# Altium schematic sheet TrickleCharging.SchDoc
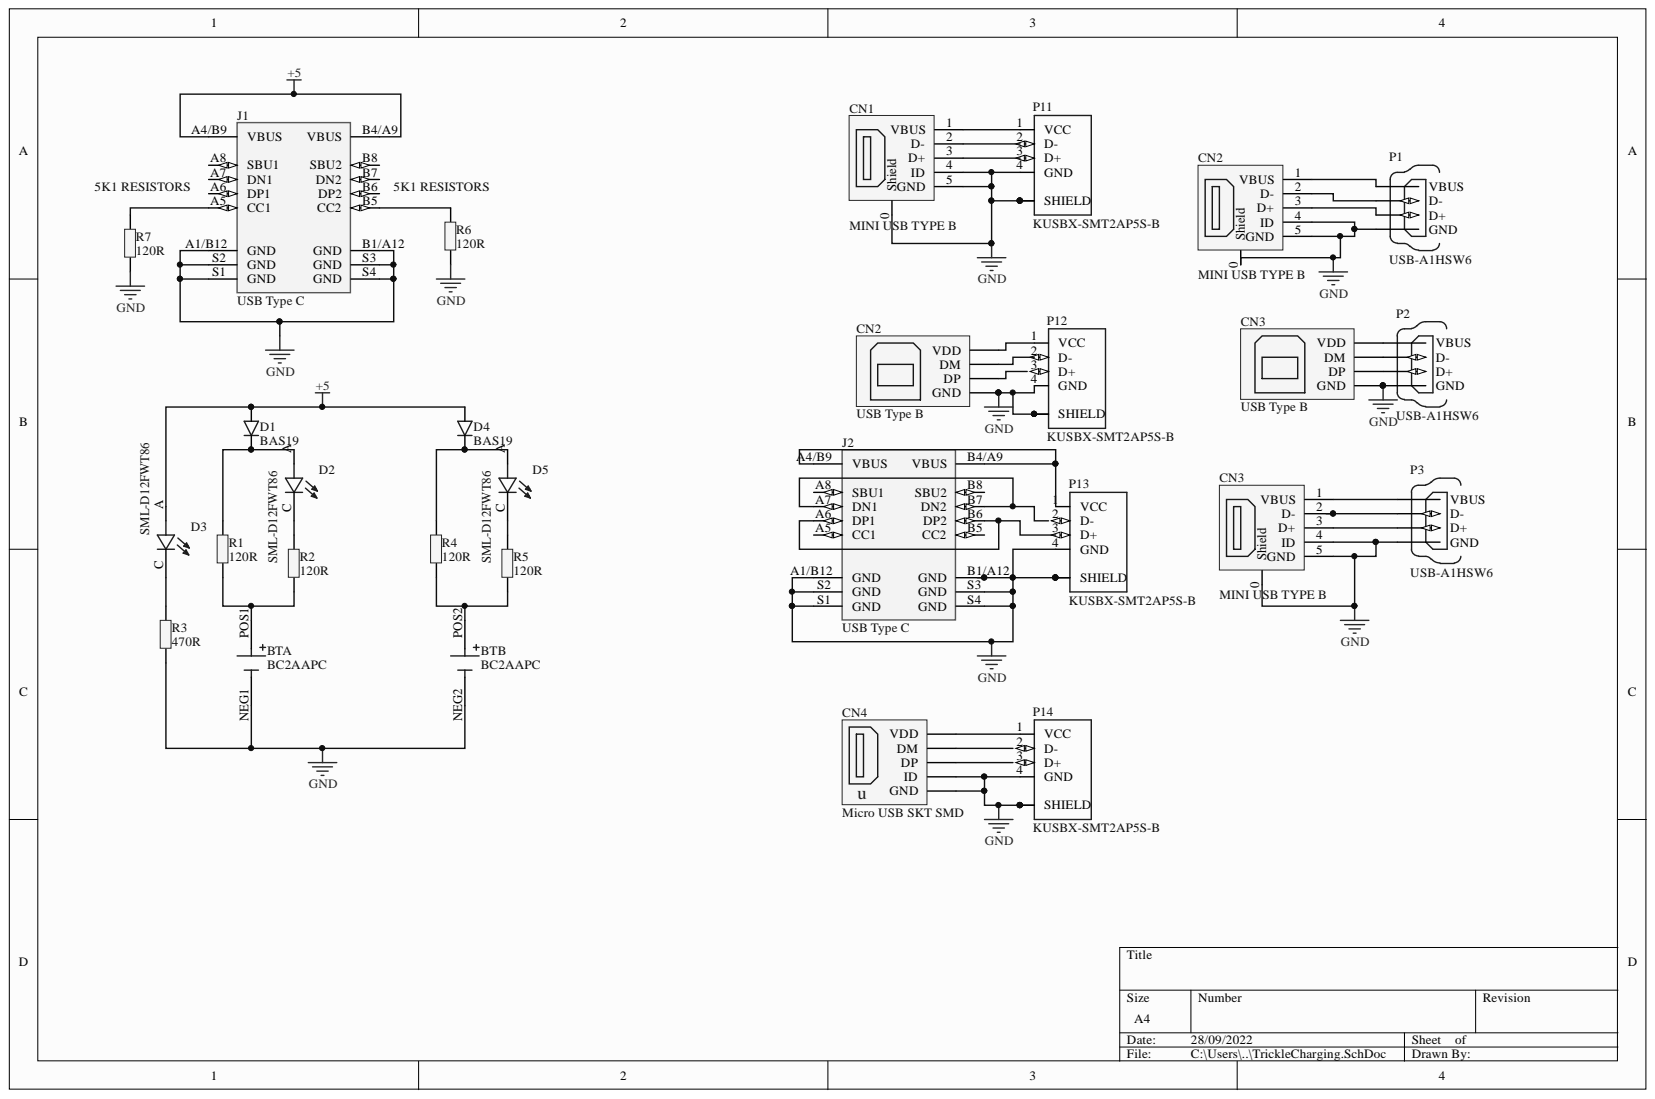
[diagram p0: TrickleCharging.SchDoc]

## Parts
BT  BC2AAPC  [BAT_BC2AAPC - dompact]  (parts A,B)
CN1  MINI USB TYPE B  [MINI USB-B]
CN2  MINI USB TYPE B  [MINI USB-B]
CN2  USB Type B  [USB-B-S-RA]
CN3  USB Type B  [USB-B-S-RA]
CN3  MINI USB TYPE B  [MINI USB-B]
CN4  Micro USB SKT SMD  [10103594-0001LF]
D1  BAS19  [SOT-23]
D2  SML-D12FWT86  [LED_SML-D12FWT86]
D3  SML-D12FWT86  [LED_SML-D12FWT86]
D4  BAS19  [SOT-23]
D5  SML-D12FWT86  [LED_SML-D12FWT86]
J1  USB Type C  [GCT_USB4110-GF-A_REVB]
J2  USB Type C  [GCT_USB4110-GF-A_REVB]
P1  USB-A1HSW6  [OST_USB-A1HSW6]
P2  USB-A1HSW6  [OST_USB-A1HSW6]
P3  USB-A1HSW6  [OST_USB-A1HSW6]
P11  KUSBX-SMT2AP5S-B  [KYCON_KUSBX-SMT2AP5S-B]
P12  KUSBX-SMT2AP5S-B  [KYCON_KUSBX-SMT2AP5S-B]
P13  KUSBX-SMT2AP5S-B  [KYCON_KUSBX-SMT2AP5S-B]
P14  KUSBX-SMT2AP5S-B  [KYCON_KUSBX-SMT2AP5S-B]
R1  120R  [0603]
R2  120R  [0603]
R3  470R  [0603]
R4  120R  [0603]
R5  120R  [0603]
R6  120R  [0603]
R7  120R  [0603]

## Nets
+5: D1.1 D3.A D4.1 J1.A4/B9(VBUS) J1.B4/A9(VBUS)
GND: BT.NEG1 BT.NEG2 CN1.0(Shield) CN1.4(ID) CN1.5(GND) CN2.0(Shield) CN2.4 CN2.5(GND) CN3.0(Shield) CN3.4 CN3.5(GND) CN4.4(ID) CN4.5(GND) J1.A1/B12(GND) J1.B1/A12(GND) J1.S1(GND) J1.S2(GND) J1.S3(GND) J1.S4(GND) J2.A1/B12(GND) J2.B1/A12(GND) J2.S1(GND) J2.S2(GND) J2.S3(GND) J2.S4(GND) P1.4 P2.4 P3.4 P11.4(GND) P11.P1(SHIELD) P11.P2 P12.4(GND) P12.P1(SHIELD) P12.P2 P13.4(GND) P13.P1(SHIELD) P13.P2 P14.4(GND) P14.P1(SHIELD) P14.P2 R3.1 R6.1 R7.1
NetBT_POS1: BT.POS1 R1.1 R2.1
NetBT_POS2: BT.POS2 R4.1 R5.1
NetCN1_1: CN1.1(VBUS) P11.1(VCC)
NetCN1_2: CN1.2(D-) P11.2(D-)
NetCN1_3: CN1.3(D+) P11.3(D+)
NetCN2_1: CN2.1 P1.1 P12.1(VCC)
NetCN2_2: CN2.2 P1.2 P12.2(D-)
NetCN2_3: CN2.3 P1.3
NetCN3_1: CN3.1 P2.1 P3.1
NetCN3_2: CN3.2 P2.2 P3.2
NetCN3_3: CN3.3 P2.3 P3.3
NetCN4_1: CN4.1(VDD) P14.1(VCC)
NetD1_3: D1.3 D2.A R1.2
NetD2_C: D2.C R2.2
NetD3_C: D3.C R3.2
NetD4_3: D4.3 D5.A R4.2
NetD5_C: D5.C R5.2
NetJ1_A5: J1.A5(CC1) R7.2
NetJ1_B5: J1.B5(CC2) R6.2
NetJ2_A4/B9: J2.A4/B9(VBUS) J2.B4/A9(VBUS) P13.1(VCC)
NetJ2_A6: J2.A6(DP1) J2.B6(DP2) P13.3(D+)
NetJ2_A7: J2.A7(DN1) J2.B7(DN2)

Not connected: CN4 2(DM) 3(DP); J1 A6(DP1) A7(DN1) A8(SBU1) B6(DP2) B7(DN2) B8(SBU2); J2 A5(CC1) A8(SBU1) B5(CC2) B8(SBU2); P12 3(D+); P13 2(D-); P14 2(D-) 3(D+)

## Library data of the parts
BT = BC2AAPC: BATTERY HOLDER AA 2 CELL PC PIN; MF: MPD; Availability: Unavailable; Component Kind: Standard; MP: BC2AAPC; Package: None; Price: None; SnapEDA_Link: https://www.snapeda.com/parts/BC2AAPC/MPD/view-part/4389051/?ref=snap; Library Name: [0801] BatteryAAholder_Dual.SchLib
CN1-CN3 = MINI USB TYPE B: USB Mini B Socket; UA P/N: 9786465; Component Kind: Standard; LatestRevisionDate: 12/03/20; Library Name: [0502] USB connectors.IntLib; Type: MOLEX 548190519; Value: MINI USB TYPE B
CN2 CN3 = USB Type B: Standard USB Type B Socket; UA P/N: 211-2373; Component Kind: Standard; LatestRevisionDate: 12/03/20; Library Name: [0502] USB connectors.IntLib; Type: USB-B-S-RA; Value: USB Type B
CN4 = Micro USB SKT SMD: USB MicroB Socket; UA P/N: 229-3752; Component Kind: Standard; LatestRevisionDate: 12/03/20; Library Name: [0502] USB connectors.IntLib; Type: Micro USB SKT SMD; Value: Micro USB SKT SMD
D1 D4 = BAS19: Diode Standard 250V 200mA Surface Mount SOT-23-3 _TO-236_; MF: onsemi; MP: BAS19LT1G; Availability: Unavailable; Component Kind: Standard; LCSC: C232582; Library Name: [0304]BAS19_SOT23.IntLib; Package: SOT-23-3 Rectron; Price: US$0.0277; SnapEDA_Link: https://www.snapeda.com/parts/BAS21/ON%20Semiconductor/view-part/2747894/?ref=snap; Value: BAS19
D2 D3 D5 = SML-D12FWT86: Green 565nm LED Indication - Discrete 2.2V 0603 (1608 Metric); MF: Rohm; Availability: Good; Check_prices: https://www.snapeda.com/parts/SML-D12FWT86/Rohm/view-part/2753923/?ref=eda; Component Kind: Standard; Library Name: [0401]LED0603_GREEN_SMT_MP008275.IntLib; MP: SML-D12FWT86; Package: 0603 Rohm; Price: None; Purchase-URL: https://pricing.snapeda.com/search/part/SML-D12FWT86/?ref=eda; SnapEDA_Link: https://www.snapeda.com/parts/SML-D12FWT86/Rohm/view-part/2753923/?ref=snap; Value: GREEN
J1 J2 = USB4110-GF-A_REVB: USB-C (USB TYPE-C) USB 2.0 Type C. Receptacle Connector 24 (16+8 Dummy) Position Surface Mount, Right Angle; Through Hole; MF: GCT; Availability: Warning; Check_prices: https://www.snapeda.com/parts/USB4110-GF-A/Global%20Connector%20Technology/view-part/4001167/?ref=eda; Component Kind: Standard; Library Name: [0502]USB_C_2-0_SMD_RA_USB4110-GF.IntLib; Mouser: 640-USB4110-GF-A; MP: USB4110-GF-A; Package: None; Price: None; Purchase-URL: https://pricing.snapeda.com/search/part/USB4110-GF-A/?ref=eda; SnapEDA_Link: https://www.snapeda.com/parts/USB4110-GF-A/Global%20Connector%20Technology/view-part/4001167/?ref=snap; Value: USB Type C
P1-P3 = USB-A1HSW6: USB - A Receptacle Connector 4 Position Through Hole, Right Angle; Availability: Unavailable; Component Kind: Standard; Library Name: USB-A1HSW6.IntLib; MP: USB-A1HSW6; Package: None; Price: None
P11-P14 = KUSBX-SMT2AP5S-B: USB-A _USB TYPE-A_ Plug Connector 4 Position Surface Mount, Right Angle; Through Hole; MF: Kycon; Availability: Unavailable; Component Kind: Standard; Library Name: KUSBX-SMT2AP5S-B.IntLib; MP: KUSBX-SMT2AP5S-B; Package: None; Price: None; SnapEDA_Link: https://www.snapeda.com/parts/KUSBX-SMT2AP5S-B/Kycon/view-part/285961/?ref=snap
R1 R2 R4-R7 = RES0603_120R: 1/10W ±1% 120Ω ±100ppm/℃ 0603; Component Kind: Standard; Library Name: [0001]RES0603_SMD_120R.IntLib; Price: US$0.0018; Type: THICK FILM; Value: 120R
R3 = RES0603_470R: 1/10W ±1% 470Ω ±100ppm/℃ 0603; Component Kind: Standard; Library Name: [0001]RES0603_SMD_470R.IntLib; Price: US$0.0018; Type: THICK FILM; Value: 470R
